# Altium schematic sheet Power.SchDoc
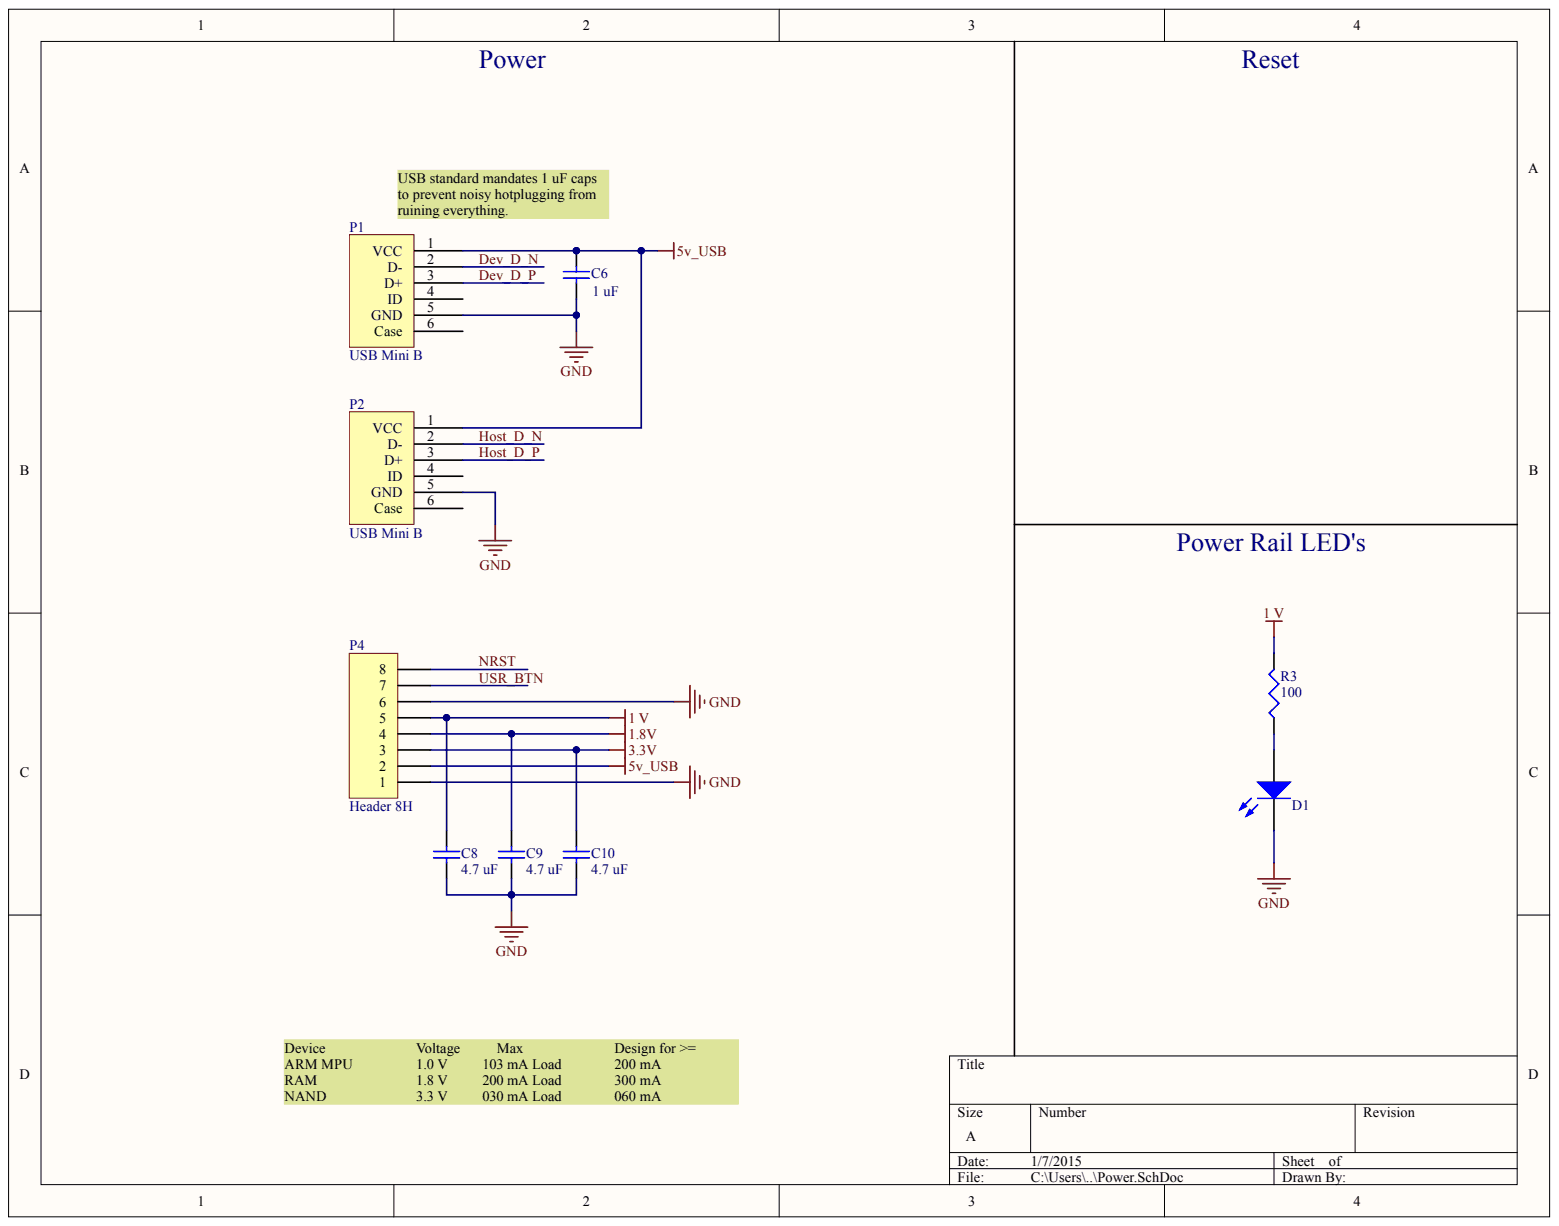
[diagram p0: Power.SchDoc]

## Parts
C6  value 1 uF  [0402]  (hidden comment: CL05A105KQ5NNNC)
C8  value 4.7 uF  [1608[0603]]  (hidden comment: CL10B475KQ8NQNC)
C9  value 4.7 uF  [1608[0603]]  (hidden comment: CL10B475KQ8NQNC)
C10  value 4.7 uF  [1608[0603]]  (hidden comment: CL10B475KQ8NQNC)
D1  LSQ976-Z  [LSQ976-Z]  (value not drawn)
P1  USB Mini B  [USB Mini B]
P2  USB Mini B  [USB Mini B]
P4  Header 8H  [Header_8_Right]
R3  value 100  [J1-0603]  (hidden comment: RC0603JR-07100RL)

## Nets
1 V: C8.2 P4.5 R3.2
1.8V: C9.2 P4.4
3.3V: C10.2 P4.3
5V_USB: C6.2 P1.1(VCC) P2.1(VCC) P4.2
GND: C6.1 C8.1 C9.1 C10.1 D1.C P1.5(GND) P2.5(GND) P4.1 P4.6
DEV_D_N: P1.2(D-)
DEV_D_P: P1.3(D+)
HOST_D_N: P2.2(D-)
HOST_D_P: P2.3(D+)
NRST: P4.8
USR_BTN: P4.7
NetD1_A: D1.A R3.1

Not connected: P1 4(ID) 6(Case); P2 4(ID) 6(Case)

## Library data of the parts
C6 = CL05A105KQ5NNNC: Cap Ceramic 1uF 6.3V X5R 10% SMD 0402; Component Kind: Standard; LatestRevisionDate: 29-May-2009; LatestRevisionNote: IPC-7351 Footprint Added.; PackageDescription: Chip Capacitor; PackageReference: C1206; Published: 8-Jun-2000; Signal Integrity: Cap Semi; Simulation: CAP; Supplier 1: Digi-Key; Supplier 2: Arrow; Supplier Part Number 1: 1276-1010-1-ND; Supplier Part Number 2: _TToi4WPdvcZSNXTq9nwNqdJ2ov5c8o4dzi8yEgOGKwZVywxIBNyoAQstvnyfZZ0; Library Name: Linux_Board_SCHEM_LIB.SchLib; Publisher: Altium Limited
C8-C10 = CL10B475KQ8NQNC: CAP CER 4.7UF 6.3V 10% X7R 0603; Component Kind: Standard; LatestRevisionDate: 29-May-2009; LatestRevisionNote: IPC-7351 Footprint Added.; PackageDescription: Chip Capacitor; PackageReference: C1206; Published: 8-Jun-2000; Signal Integrity: Cap Semi; Simulation: CAP; Supplier 1: Digi-Key; Supplier Part Number 1: 1276-2087-1-ND; Library Name: Linux_Board_SCHEM_LIB.SchLib; Publisher: Altium Limited
  C8: VALUE: 4.7 uF
D1 = LSQ976-Z: OSRAM - LED, SMD, RED, 180MCD, 633NM; Component Kind: Standard; Signal Integrity: Guessed Model; Supplier 1: Mouser; Supplier 2: Newark; Supplier Part Number 1: N/A; Supplier Part Number 2: 05M0541; Library Name: Linux_Board_SCHEM_LIB.SchLib
P1 P2 = USB Mini B: USB Mini B connector; Component Kind: Standard; Signal Integrity: Guessed Model; Library Name: Linux_Board_SCHEM_LIB.SchLib
P4 = Header 8H: Header, 8-Pin, Right Angle_Silkscreen_fixed; Component Kind: Standard; LatestRevisionDate: 17-Jul-2002; LatestRevisionNote: Re-released for DXP Platform.; Signal Integrity: Connector; Library Name: Linux_Board_SCHEM_LIB.SchLib; Publisher: Altium Limited
R3 = RC0603JR-07100RL: RES SMD 100 OHM 5% 1/10W 0603; Component Kind: Standard; LatestRevisionDate: 29-May-2009; LatestRevisionNote: IPC-7351 Footprint Added.; PackageDescription: Chip Resistor; PackageReference: J1-0603; Published: 8-Jun-2000; Signal Integrity: Res; Simulation: RESISTOR; Supplier 1: Digi-Key; Supplier Part Number 1: 311-100GRCT-ND; Library Name: Linux_Board_SCHEM_LIB.SchLib; Publisher: Altium Limited
